# Altium schematic sheet TeensyBOBv2.SchDoc
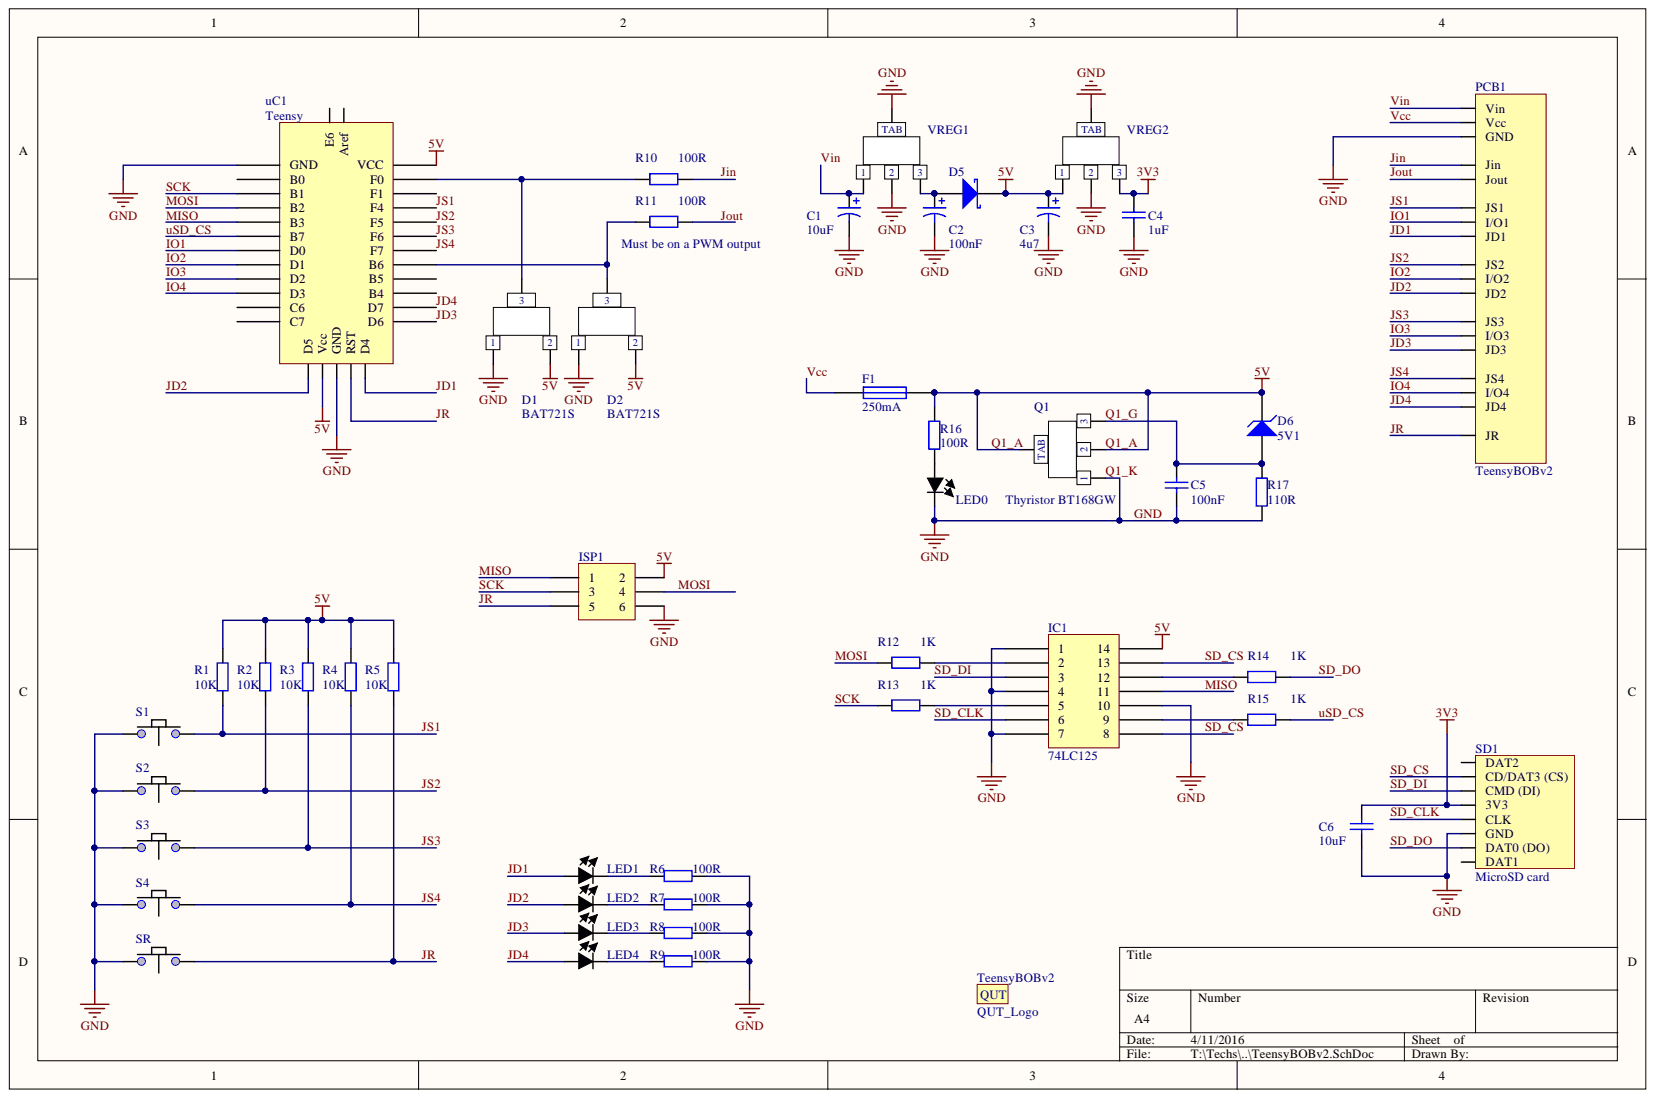
[diagram p0: TeensyBOBv2.SchDoc]

## Parts
C1  value 10uF  [6-0805_M]  (hidden comment: Cap Pol1)
C2  value 100nF  [6-0805_M]  (hidden comment: Cap Pol1)
C3  value 4u7  [6-0805_M]  (hidden comment: Cap Pol1)
C4  value 1uF  [6-0805_M]  (hidden comment: Cap)
C5  value 100nF  [6-0805_M]  (hidden comment: Cap)
C6  value 10uF  [6-0805_M]  (hidden comment: Cap)
D1  BAT721S  [SOT23-3N]
D2  BAT721S  [SOT23-3N]
D5  D Schottky  [SMB]  (value not drawn)
D6  5V1  [SMB]
F1  250mA  [C1206]
IC1  74LC125  [SOIC127P600X175-14N]
ISP1  Header 3X2  [HDR2X3]  (value not drawn)
LED0  LED*  [LED 5mm]
LED1  LED*  [LED 5mm]
LED2  LED*  [LED 5mm]
LED3  LED*  [LED 5mm]
LED4  LED*  [LED 5mm]
PCB1  TeensyBOBv2  [TeensyBOB]
Q1  Thyristor BT168GW  [SOT233-4N]
R1  value 10K  [6-0805_M]  (hidden comment: Res2)
R2  value 10K  [6-0805_M]  (hidden comment: Res2)
R3  value 10K  [6-0805_M]  (hidden comment: Res2)
R4  value 10K  [6-0805_M]  (hidden comment: Res2)
R5  value 10K  [6-0805_M]  (hidden comment: Res2)
R6  value 100R  [6-0805_M]  (hidden comment: Res2)
R7  value 100R  [6-0805_M]  (hidden comment: Res2)
R8  value 100R  [6-0805_M]  (hidden comment: Res2)
R9  value 100R  [6-0805_M]  (hidden comment: Res2)
R10  value 100R  [6-0805_M]  (hidden comment: Res2)
R11  value 100R  [6-0805_M]  (hidden comment: Res2)
R12  value 1K  [6-0805_M]  (hidden comment: Res2)
R13  value 1K  [6-0805_M]  (hidden comment: Res2)
R14  value 1K  [6-0805_M]  (hidden comment: Res2)
R15  value 1K  [6-0805_M]  (hidden comment: Res2)
R16  value 100R  [6-0805_M]  (hidden comment: Res2)
R17  value 110R  [6-0805_M]  (hidden comment: Res2)
S1  Switch_PCB_Push  [SMD 4 contact switch]  (value not drawn)
S2  Switch_PCB_Push  [SMD 4 contact switch]  (value not drawn)
S3  Switch_PCB_Push  [SMD 4 contact switch]  (value not drawn)
S4  Switch_PCB_Push  [SMD 4 contact switch]  (value not drawn)
SD1  MicroSD card  [Micro SD card]
SR  Switch_PCB_Push  [SMD 4 contact switch]  (value not drawn)
TeensyBOBv2  QUT_Logo  [QUT_Logo]
uC1  Teensy  [Teensy full]
VREG1  FT_SOT223  [SOT233-4N]  (value not drawn)
VREG2  FT_SOT223  [SOT233-4N]  (value not drawn)

## Nets
3V3: C4.2 C6.2 SD1.4(3V3) VREG2.3
GND: C1.2 C2.2 C3.2 C4.1 C5.1 C6.1 D1.1 D2.1 IC1.1 IC1.4 IC1.7 IC1.10 ISP1.6 LED0.2 PCB1.GND Q1.1 R6.2 R7.2 R8.2 R9.2 R17.1 S1.0 S2.0 S3.0 S4.0 SD1.6(GND) SR.0 uC1.0(GND) uC1.26(GND) VREG1.2 VREG1.4 VREG2.2 VREG2.4
IO1: PCB1.4(I/O1) uC1.6(D0)
IO2: PCB1.7(I/O2) uC1.7(D1)
IO3: PCB1.10(I/O3) uC1.8(D2)
IO4: PCB1.13(I/O4) uC1.9(D3)
JD1: LED1.1 PCB1.5(JD1) uC1.24(D4)
JD2: LED2.1 PCB1.8(JD2) uC1.28(D5)
JD3: LED3.1 PCB1.11(JD3) uC1.23(D6)
JD4: LED4.1 PCB1.14(JD4) uC1.22(D7)
JIN: PCB1.1(Jin) R10.2
JOUT: PCB1.2(Jout) R11.2
JR: ISP1.5 PCB1.15(JR) R5.2 SR.1 uC1.25(RST)
JS1: PCB1.3(JS1) R1.2 S1.1 uC1.15(F4)
JS2: PCB1.6(JS2) R2.2 S2.1 uC1.16(F5)
JS3: PCB1.9(JS3) R3.2 S3.1 uC1.17(F6)
JS4: PCB1.12(JS4) R4.2 S4.1 uC1.18(F7)
MISO: IC1.11 ISP1.1 uC1.4(B3)
MOSI: ISP1.4 R12.1 uC1.3(B2)
Q1_A: C3.1 D1.2 D2.2 D5.2 D6.2 F1.2 IC1.14 ISP1.2 Q1.2 Q1.4 R1.1 R2.1 R3.1 R4.1 R5.1 R16.2 uC1.12(VCC) uC1.27(Vcc) VREG2.1
Q1_G: C5.2 D6.1 Q1.3 R17.2
SCK: ISP1.3 R13.1 uC1.2(B1)
SD_CLK: IC1.6 SD1.5(CLK)
SD_CS: IC1.8 IC1.13 SD1.2(CD/DAT3_(CS))
SD_DI: IC1.3 SD1.3(CMD_(DI))
SD_DO: R14.2 SD1.7(DAT0_(DO))
USD_CS: R15.2 uC1.5(B7)
VCC: F1.1 PCB1.Vcc
VIN: C1.1 PCB1.Vin VREG1.1
NetC2_1: C2.1 D5.1 VREG1.3
NetD1_3: D1.3 R10.1 uC1.13(F0)
NetD2_3: D2.3 R11.1 uC1.19(B6)
NetIC1_2: IC1.2 R12.2
NetIC1_5: IC1.5 R13.2
NetIC1_9: IC1.9 R15.1
NetIC1_12: IC1.12 R14.1
NetLED0_1: LED0.1 R16.1
NetLED1_2: LED1.2 R6.1
NetLED2_2: LED2.2 R7.1
NetLED3_2: LED3.2 R8.1
NetLED4_2: LED4.2 R9.1

Not connected: SD1 1(DAT2) 8(DAT1); uC1 1(B0) 10(C6) 11(C7) 14(F1) 20(B5) 21(B4) 29(E6) 30(Aref)

## Library data of the parts
C1-C3 = Cap Pol1: Polarized Capacitor (Radial); Component Kind: Standard; LatestRevisionDate: 17-Jul-2002; LatestRevisionNote: Re-released for DXP Platform.; Library Name: Miscellaneous Devices.IntLib; PackageDescription: Polarized Capacitor (Radial); 2 Leads; PackageReference: RB7.6-15; Published: 8-Jun-2000; Signal Integrity: Cap Pol; Simulation: CAP; Publisher: Altium Limited
C4-C6 = Cap: Capacitor; Component Kind: Standard; LatestRevisionDate: 17-Jul-2002; LatestRevisionNote: Re-released for DXP Platform.; Library Name: Miscellaneous Devices.IntLib; PackageDescription: Radial Cap, Thru-Hole; 2 Leads; 0.3 in Pin Spacing; PackageReference: RAD-0.3; Published: 8-Jun-2000; Signal Integrity: Cap; Simulation: CAP; Publisher: Altium Limited
D1 D2 = FT_SOT23-3: Component Kind: Standard; Library Name: QUT.IntLib
D5 = D Schottky: Schottky Diode; Code_JEDEC: DO-214-AA; Component Kind: Standard; LatestRevisionDate: 17-Jul-2002; LatestRevisionNote: Re-released for DXP Platform.; Library Name: Miscellaneous Devices.IntLib; PackageDescription: DO-214-AA/SMB; 2 C-Bend Leads; Body 5.3 x 3.6 mm, inc. leads (LxW); PackageReference: SMB; Published: 8-Jun-2000; Signal Integrity: D Schottky; Simulation: SKYDIODE; Publisher: Altium Limited
D6 = D Zener: Zener Diode; Component Kind: Standard; LatestRevisionDate: 17-Jul-2002; LatestRevisionNote: Re-released for DXP Platform.; Library Name: Miscellaneous Devices.IntLib; PackageDescription: Diode, Thru-Hole; 2 Leads; 0.7 in Pin Spacing; PackageReference: DIODE-0.7; Published: 8-Jun-2000; Signal Integrity: D Zener; Simulation: ZENER; Supplier: E14 1431159; Publisher: Altium Limited
F1 = Fuse 1: Fuse; Component Kind: Standard; LatestRevisionDate: 17-Jul-2002; LatestRevisionNote: Re-released for DXP Platform.; Library Name: Miscellaneous Devices.IntLib; PackageDescription: Thru-Hole; 2 Leads; PackageReference: PIN-W2/E2.8; Published: 8-Jun-2000; Simulation: FUSE; Publisher: Altium Limited
IC1 = IC_14PIN: Component Kind: Standard; Library Name: QUT.IntLib
ISP1 = Header 3X2: Header, 3-Pin, Dual row; Component Kind: Standard; LatestRevisionDate: 17-Jul-2002; LatestRevisionNote: Re-released for DXP Platform.; Library Name: Miscellaneous Connectors.IntLib; Signal Integrity: Connector; Publisher: Altium Limited
LED0-LED4 = LED: Component Kind: Standard; Supplier: E14 1716764; Library Name: QUT.IntLib
Q1 = FT_SOT223: Component Kind: Standard; Library Name: QUT.IntLib
R1-R17 = Res2: Resistor; Component Kind: Standard; LatestRevisionDate: 17-Jul-2002; LatestRevisionNote: Re-released for DXP Platform.; Library Name: Miscellaneous Devices.IntLib; PackageReference: AXIAL-0.4; Published: 8-Jun-2000; Signal Integrity: Res; Simulation: RESISTOR; Publisher: Altium Limited
uC1 = Teensy full: Component Kind: Standard; Library Name: QUT.IntLib
PCB1 = TeensyBOBv2: Component Kind: Standard; Library Name: TeensyBOBv2.SchLib
S1-S4 SR = Switch_PCB_Push: Component Kind: Standard; Supplier: E14 1570392; Library Name: QUT.IntLib
SD1 = MicroSD card: Component Kind: Standard; Library Name: QUT.IntLib
TeensyBOBv2 = QUT_Logo: Component Kind: Standard; Library Name: QUT.IntLib
VREG1 VREG2 = FT_SOT223: Component Kind: Standard; Library Name: QUT.IntLib
